# Altium schematic sheet AOut_Isolator.SchDoc
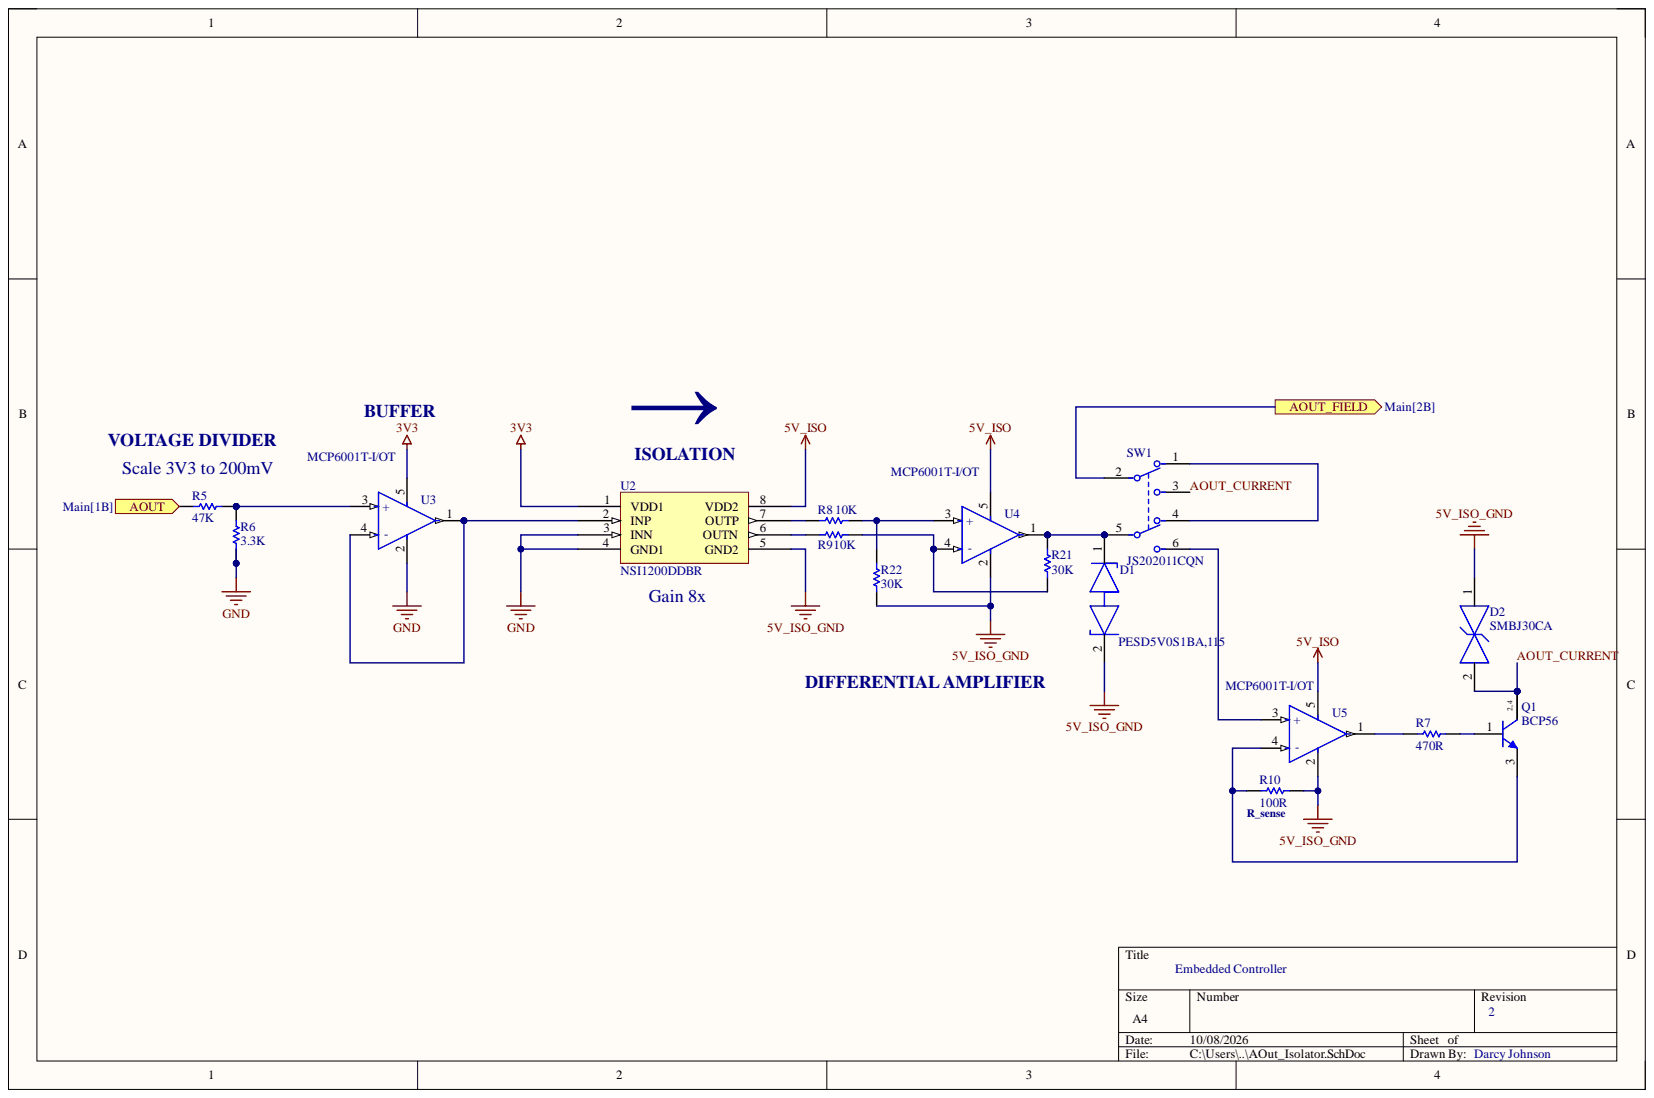
[diagram p0: AOut_Isolator.SchDoc]

## Parts
D1  PESD5V0S1BA,115  [FP-SOD323-CA-MFG]
D2  SMBJ30CA  [FP-SMBJ30CAHE3-52-MFG]
Q1  BCP56  [ONSC-SOT-223-4-318E-04_V]
R5  47K  [RESC1608X55X30NL15T15]
R6  3.3K  [RESC1609X55X30ML15T15]
R7  470R  [RESC1608X55X30NL15T15]
R8  10K  [RESC1609X55X30LL15T15]
R9  10K  [RESC1609X55X30LL15T15]
R10  100R  [RESC3216X70X50NL05T20]
R21  30K  [RESC1608X55X30LL15T15]
R22  30K  [RESC1608X55X30LL15T15]
SW1  JS202011CQN  [FP-JS202011CQN-MFG - duplicate]
U2  NSI1200DDBR  [NSI1200DDBR]
U3  MCP6001T-I/OT  [FP-C04-091-OT-IPC_A]
U4  MCP6001T-I/OT  [FP-C04-091-OT-IPC_A]
U5  MCP6001T-I/OT  [FP-C04-091-OT-IPC_A]

## Ports
AOUT, AOUT_FIELD

## Nets
3V3: U2.1(VDD1) U3.5
5V_ISO: U2.8(VDD2) U4.5 U5.5
5V_ISO_GND: D1.2 D2.1 R10.1 R22.2 U2.5(GND2) U4.2 U5.2
GND: R6.2 U2.3(INN) U2.4(GND1) U3.2
AOUT: R5.1 ; port AOUT
AOUT_CURRENT: D2.2 Q1.2 Q1.4 SW1.3
AOUT_FIELD: SW1.2 ; port AOUT_FIELD
NetD1_1: D1.1 R21.1 SW1.5 U4.1
NetQ1_1: Q1.1 R7.2
NetQ1_3: Q1.3 R10.2 U5.4(-)
NetR5_2: R5.2 R6.1 U3.3(+)
NetR7_1: R7.1 U5.1
NetR8_1: R8.1 U2.7(OUTP)
NetR8_2: R8.2 R22.1 U4.3(+)
NetR9_1: R9.1 U2.6(OUTN)
NetR9_2: R9.2 R21.2 U4.4(-)
NetSW1_1: SW1.1 SW1.4
NetSW1_6: SW1.6 U5.3(+)
NetU2_2: U2.2(INP) U3.1 U3.4(-)

## Library data of the parts
D1 = CMP-14432-000001-1: TVS DIODE 5V 14V SOD323; Component Kind: Standard; Library Name: Altium Content Vault
D2 = CMP-02577-000018-1: TVS DIODE 30V 48.4V DO214AA; Manufacturer: Vishay Semiconductor Diodes Division; Manufacturer Part Number: SMBJ30CAHE3/52; Automotive Grade: Grade 0; Breakdown Voltage: 33.3V; Direction: Bidirectional; Max Junction Temp: +150°C; Number Of Channels: 1; Peak Pulse Current: 12.4A; Peak Pulse Power: 600W; Standoff Height: 0mm; Automotive: Yes; Category: Diode; Clamping Voltage: 48.4V; Component Kind: Standard; ComponentLink2Description: Datasheet; ComponentLink2URL: http://www.vishay.com/docs/88392/smbj.pdf; Device Class L1: Circuit Protection; Device Class L2: TVS Diodes; Device Class L3: unset; Digikey Description: TVS DIODE 30V 48.4V DO214AA; Footprint Url: http://www.vishay.com/docs/95401/smb.pdf; Height: 2.44mm; Lead Free: Yes; Library Name: Altium Content Vault; Mouser Description: Diode TVS Single Bi-Dir 30V 600W 2-Pin DO-214AA T/R; Octopart: https://octopart.com/smbj30cahe3%2F52-vishay-43725255; Package: DO-214AA; Reverse Standoff Voltage: 30V; RoHS: TRUE; Temperature: -55°C to +150°C; Temperature Range High: +150°C; Temperature Range Low: -55°C
Q1 = CMP-1048-00522-1: NPN Silicon Epitaxial Transistor, 4-Pin SOT-223, Pb-Free, Tape and Reel; Manufacturer: On Semiconductor; PartNumber: SBCP56T1G; IC Continuous (A): 1; Component Kind: Standard; ComponentLink1Description: Manufacturer URL; ComponentLink1URL: http://www.onsemi.com/; ComponentLink2Description: Datasheet; ComponentLink2URL: http://www.onsemi.com/pub_link/Collateral/BCP56T1-D.PDF; ComponentLink3Description: Package Specification; ComponentLink3URL: http://www.onsemi.com/pub_link/Collateral/318E-04.PDF; DatasheetVersion: Rev. 8; Library Name: Altium Content Vault; Mounting Technology: Surface Mount; PackageDescription: 4-Pin Small Outline Transistor, Body 6.5 x 3.5 mm, Pitch 2.3 mm; PackageReference: SOT-223-4-318E-04; PackageVersion: Rev. N, 08/2009; Packing: Tape and Reel; Polarity: NPN; RoHS: TRUE
R5 = CMP-2000-00523-2: Chip Resistor, 47 KOhm, +/- 1%, 0.1 W, -55 to 155 degC, 0603 (1608 Metric), RoHS, Tape and Reel; Case\Package: 0603; Forward Current: 20 mA; Forward Voltage: 2.1 V; Illumination Colour: Red; Lens Style: Clear; Luminous Intensity: 63 mcd; Nominal Wavelength: 639 nm; Power Rating: 90 mW; Viewing Angle: 160 degrees; Case Code (Imperial): 0603; Case Code (Metric): 1608; Colour: Red; Component Kind: Standard; ComponentLink1Description: Manufacturer URL; ComponentLink1URL: http://www.panasonic.com/; ComponentLink2Description: Datasheet; ComponentLink2URL: http://industrial.panasonic.com/cdbs/www-data/pdf/RDA0000/AOA0000C86.pdf; DatasheetVersion: Apr-15; Height: 0.6 mm; Length: 1.6 mm; Library Name: Altium Content Vault; Max Operating Temperature: 100 degC; Min Operating Temperature: -40 degC; Mount: Surface Mount; Nominal Vf: 2.1 V; Package Quantity: 3000; PackageDescription: 2-Pin Surface Mount Device, Body 1.6 x 0.85 mm; PackageReference: 0603; Packaging: Tape and Reel; Pins: 2; Radiation Hardening: No; REACH SVHC: No SVHC; RoHSCompliant: true; Width: 0.8 mm
R6 = CMP-2000-00436-2: Chip Resistors, 3.3 KOhm, +/- 1%, 0.1 W, 0603 (1608 Metric), -55 to 155 degC, RoHS, Tape and Reel; Manufacturer: Panasonic; Max Power Dissipation: 100mW; Temperature Coefficient: 100ppm/degreesC; Voltage Rating: 75V; Case Code (Imperial): 0603; Case Code (Metric): 1608; Case/Package: 0603; Component Kind: Standard; Composition: ThickFilm; Contact Plating: Tin; Datasheet URL: https://industrial.panasonic.com/cdbs/www-data/pdf/RDA0000/AOA0000C283.pdf; Datasheet Version: 42095.0; Depth: 0.8mm; Height: 0.022inch; Lead Free: LeadFree; Length: 1.6mm; Library Name: Altium Content Vault; Manufacturer URL: http://www.panasonic.com/; Max Operating Temperature: 155degC; Military Standard: Not; Min Operating Temperature: -55degC; Mount: SurfaceMount; Number of Pins: 2; Number of Terminations: 2; Package Description: 2-Pin Surface Mount Device, Body 1.6 x 0.85 mm; Package Quantity: 1; Package Reference: 0603; Package URL: http://www.st.com/st-web-ui/static/active/en/resource/technical/document/datasheet/CD00001320.pdf; Packaging: TapeandReel; Power Rating: 100mW; Radiation Hardening: No; REACH SVHC: NoSVHC; Resistance: 3.3KOhm; RoHSCompliant: true; Termination: SMD/SMT; Tolerance: 1%; Voltage Rating (DC): 75V; Width: 0.8mm
R7 = CMP-1012-00586-3: Precision Thick Film Chip Resistor, 4.7 KOhm, +/- 1%, -55 to 155 degC, 0603 (1608 Metric), RoHS, Tape and Reel; Case\Package: 1205; Elements: 4; Power Rating: 250 mW; Resistance: 220 Ohm; Tolerance: 5%; Voltage Rating: 50 V; Case Code (Imperial): 1206; Case Code (Metric): 3216; Component Kind: Standard; ComponentLink1Description: Manufacturer URL; ComponentLink1URL: http://www.panasonic.com/; ComponentLink2Description: Datasheet; ComponentLink2URL: http://industrial.panasonic.com/cdbs/www-data/pdf/RDA0000/AOA0000C86.pdf; DatasheetVersion: Apr-15; Height: 0.024 inch; Length: 0.126 inch; Library Name: Altium Content Vault; Max Operating Temperature: 125 degC; Min Operating Temperature: -55 degC; Mount: Surface Mount; Package Quantity: 1; PackageDescription: 2-Pin Surface Mount Device, Body 1.6 x 0.85 mm; PackageReference: 0603; Packaging: Tape and Reel; Pins: 8; Radiation Hardening: No; REACH SVHC: No SVHC; RoHSCompliant: true; Type (Resistor): Thick Film; Width: 0.063 inch
R8 R9 = CMP-1012-00623-3: Chip Resistor, 10 KOhm, +/- 1%, 0.1 W, -55 to 155 degC, 0603 (1608 Metric), RoHS, Tape and Reel; Manufacturer: Panasonic; Case\/Package: 0603; Max Power Dissipation: 100mW; Resistance: 10KOhm; Temperature Coefficient: 100ppm\/degreesC; Voltage Rating: 75V; Case Code (Imperial): 0603; Case Code (Metric): 1608; Component Kind: Standard; Composition: ThickFilm; Contact Plating: Tin; Datasheet URL: https://industrial.panasonic.com/cdbs/www-data/pdf/RDA0000/AOA0000C304.pdf; Datasheet Version: 02/2017; Depth: 0.8mm; Height: 0.45mm; Lead Free: LeadFree; Length: 1.6mm; Library Name: Altium Content Vault; Manufacturer URL: http://www.panasonic.com/; Max Operating Temperature: 155degC; Military Standard: Not; Min Operating Temperature: -55degC; Mount: SurfaceMount; Mounting Technology: SurfaceMount; Number of Pins: 2; Number of Terminations: 2; Package Description: 2-Pin Surface Mount Device, Body 1.6 x 0.85 mm; Package Quantity: 1; Package Reference: 603; Packaging: TapeandReel; Power Rating: 100mW; Radiation Hardening: No; REACH SVHC: NoSVHC; RoHSCompliant: true; Termination: SMD/SMT; Tolerance: 1%; Voltage Rating (DC): 75V; Width: 0.031inch
R10 = CMP-2003-00592-1: Manufacturer: Panasonic; Part Number: ERJ-8GEYJ241V; Component Kind: Standard; Library Name: Altium Content Vault
R21 R22 = CMP-1012-00109-2: Manufacturer: Panasonic; Part Number: ERJ-3GEYJ303V; Component Kind: Standard; Library Name: Altium Content Vault
SW1 = CMP-10038-000022-1_1: SWITCH SLIDE DPDT 300MA 6V; Actuator Color: Black; Actuator Length: 2mm; Actuator Material: Nylon; Actuator Type: Standard; Contact Current Rating: 100mA; Contact Resistance: 70mR; Electrical Life: 5000Cycles; Illumination Type: Non-Illuminated; Switch Function: On-On; Component Kind: Standard; Contact Material: Copper Alloy; Contact Plating: Silver; Current Rating: 300mA; Depth: 3.6mm; Flammability Rating: UL94 V-0; Housing Material: Nylon; Lead Free: Lead Free; Length: 9mm; Library Name: JS202011CQN .SchLib; Max Current Rating: 300mA; Max Operating Temperature: 85°C; Max Voltage Rating (DC): 6V; Min Operating Temperature: -40°C; Mount: Through Hole; Orientation: Vertical; Package Quantity: 1; Packaging: Bulk; Radiation Hardening: No; REACH SVHC: No SVHC; RoHS Compliant: Yes; Series: JS; Switch Travel: 2mm; Termination: PC Pins; Throw Configuration: DPDT; Voltage Rating (DC): 6V
U2 = NSI1200DDBR: High Reliability Reinforced Isolated Amplifier; Manufacturer: Novosense; Component Kind: Standard; ComponentLink1Description: Datasheet; ComponentLink1URL: https://file.elecfans.com/web2/M00/63/D8/poYBAGMEo2GAVfdLABzacvfTaPY750.pdf; Library Name: nsi1200.IntLib; Manufacturer Part Number: NSI1200DDBR
U3-U5 = CMP-0187-00462-3: IC OPAMP GP 1 CIRCUIT SOT23-5; Bandwidth: 1MHz; Common Mode Rejection Ratio: 60dB; Input Bias Current: 1pA; Input Offset Voltage (Vos): 4.5mV; Max Supply Voltage: 6V; Min Supply Voltage: 1.8V; Nominal Supply Current: 100uA; Number of Amplifiers: 1; Operating Supply Voltage: 5.5V; Output Current: 23mA; Power Supply Rejection Ratio (PSRR): 86dB; Slew Rate: 0.6V/µs; Voltage Gain: 112dB; Case/Package: SOT-23; Component Kind: Standard; Contact Plating: Tin; Gain Bandwidth Product: 1MHz; Height: 1.3mm; Lead Free: Lead Free; Length: 3.1mm; Library Name: Altium Content Vault; Max Operating Temperature: 85°C; Min Operating Temperature: -40°C; Mount: Surface Mount; Number of Channels: 1; Number of Circuits: 1; Number of Elements: 1; Number of Pins: 5; Operating Supply Current: 100uA; Output Current per Channel: 23mA; Package Quantity: 1; Packaging: Tape and Reel; Radiation Hardening: No; REACH SVHC: No SVHC; Unity Gain Bandwidth Product: 1MHz; Voltage: 6V; Width: 1.8mm
